# Altium schematic sheet FEZ-Bit-Power.SchDoc(FEZ-Bit-Power)
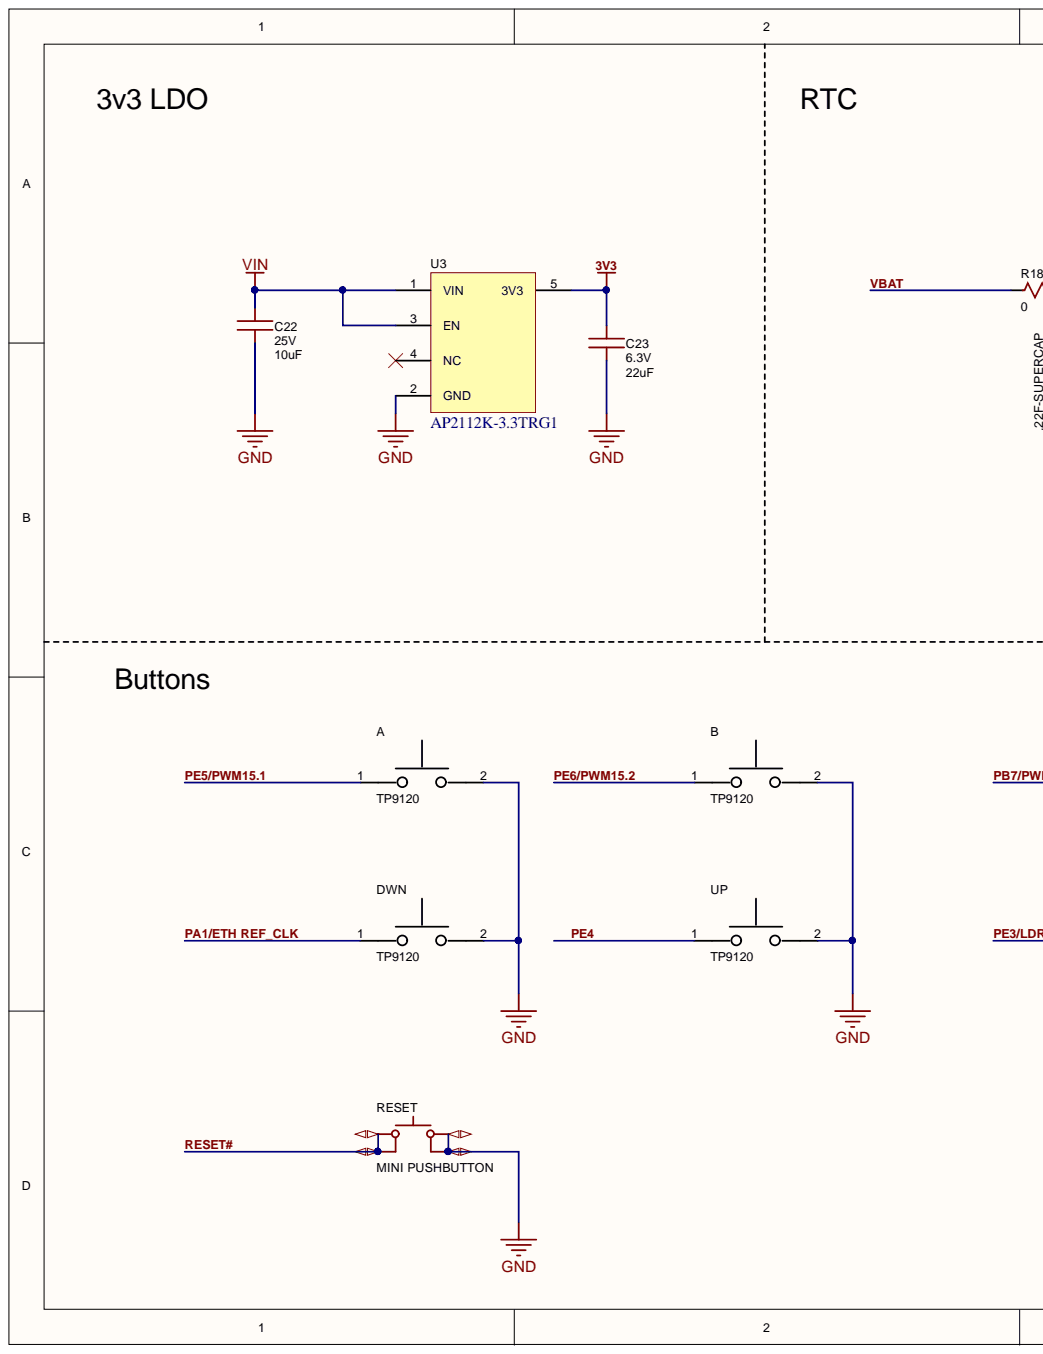
[diagram p0: FEZ-Bit-Power.SchDoc, left]
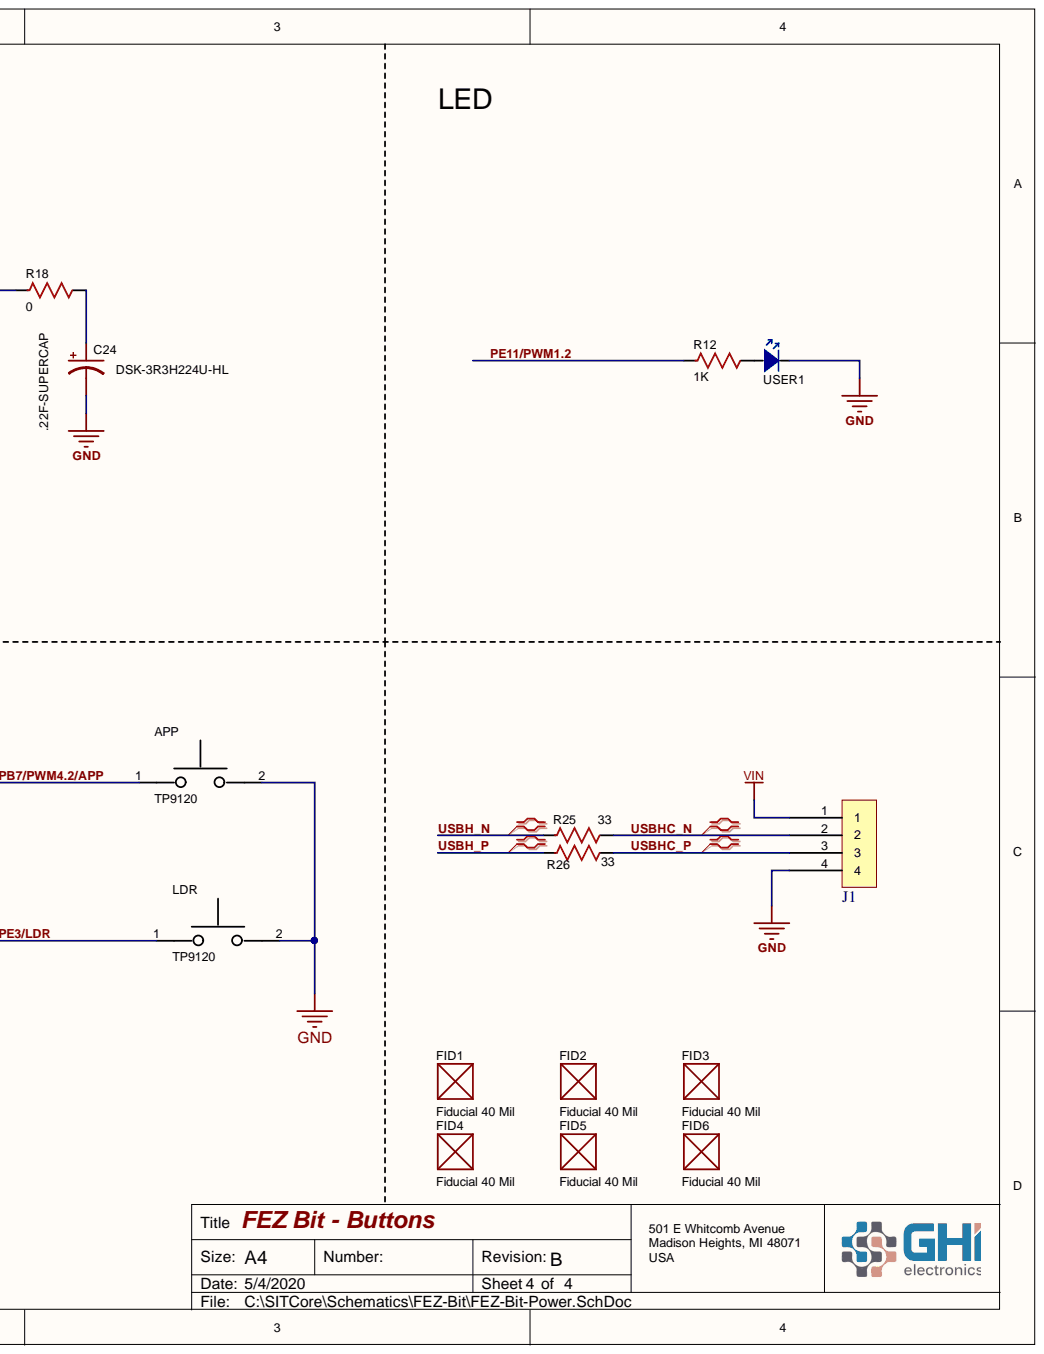
[diagram p1: FEZ-Bit-Power.SchDoc, right]

## Parts
A  value TP9120  [Button - TL9210]  (hidden comment: Button - TP9120)
APP  value TP9120  [Button - TL9210]  (hidden comment: Button - TP9120)
B  value TP9120  [Button - TL9210]  (hidden comment: Button - TP9120)
C22  value 10uF  Voltage: 25V  [C0805]  (hidden comment: 0805 Cap 10uF)
C23  value 22uF  Voltage: 6.3V  [C0805]  (hidden comment: 0805 Cap 22uF)
C24  .22F-SUPERCAP  value DSK-3R3H224U-HL  [DSK-RADIAL-6.8MM]
DWN  value TP9120  [Button - TL9210]  (hidden comment: Button - TP9120)
FID1  Fiducial 40 Mil  [Fiducial 40 Mil]  (no BOM)
FID2  Fiducial 40 Mil  [Fiducial 40 Mil]  (no BOM)
FID3  Fiducial 40 Mil  [Fiducial 40 Mil]  (no BOM)
FID4  Fiducial 40 Mil  [Fiducial 40 Mil]  (no BOM)
FID5  Fiducial 40 Mil  [Fiducial 40 Mil]  (no BOM)
FID6  Fiducial 40 Mil  [Fiducial 40 Mil]  (no BOM)
J1  M4  [1X04]  (value not drawn)
LDR  value TP9120  [Button - TL9210]  (hidden comment: Button - TP9120)
R12  value 1K  [R0603 (1608 Metric)]  (hidden comment: 0603 1K)
R18  value 0  [R0603]  (hidden comment: 0603 Res 0)
R25  value 33  [R0402]  (hidden comment: 0402 Res 33)
R26  value 33  [R0402]  (hidden comment: 0402 Res 33)
RESET  MINI_PUSHBUTTON  value MINI PUSHBUTTON  [MINI_PUSHBUTTON]
U3  AP2112K-3.3TRG1  [SOT95P280X100-5N]
UP  value TP9120  [Button - TL9210]  (hidden comment: Button - TP9120)
USER1  0603 LED Blue  [0603 LED Blue]  (value not drawn)

## Nets
3V3: C23.2 U3.5(3V3)
GND: A.2 APP.2 B.2 C22.1 C23.1 C24.- DWN.2 J1.4 LDR.2 RESET.3 RESET.4 U3.2(GND) UP.2 USER1.1
VIN: C22.2 J1.1 U3.1(VIN) U3.3(EN)
PA1/ETH REF_CLK: DWN.1
PB7/PWM4.2/APP: APP.1
PE3/LDR: LDR.1
PE4: UP.1
PE5/PWM15.1: A.1
PE6/PWM15.2: B.1
PE11/PWM1.2: R12.1
RESET#: RESET.1 RESET.2
USBH_N: R25.1
USBH_P: R26.1
USBHC_N: J1.2 R25.2
USBHC_P: J1.3 R26.2
VBAT: R18.1
NetC24_+: C24.+ R18.2
NetR12_2: R12.2 USER1.2

Not connected: U3 4(NC)

## Library data of the parts
C22 = 0805 Cap 10uF: 10uF ±10% 25V X5R 0805 Multilayer Ceramic Capacitors MLCC - SMD/SMT RoHS; Mfr.Part #: CC0805KKX5R8BB106; Manufacturer: YAGEO; Tolerance: ±10%; Package: 0805; LCSC Part #: C89831; Component Kind: Standard; Category: Ceramic; Capacitance: 10uF; Library Name: GHI-Passives.SchLib
C23 = 0805 Cap 22uF: 22uF ±20% 6.3V X5R 0805 Multilayer Ceramic Capacitors MLCC - SMD/SMT RoHS; Mfr.Part #: CL21A226MQCLRNC; Tolerance: ±20%; Package: 0805; LCSC Part #: C307530; Component Kind: Standard; Category: Ceramic; Capacitance: 22uF; Library Name: GHI-Passives.SchLib
C24 = .22F-SUPERCAP: ELNA DSK-621 - 220mF Electric Double Layer Capacitor (EDLC/SuperCapacitor)    A 220 mF SuperCapacitor. Used for backup power on devices with low standby current; Manufacturer: Elna America; Mfr.Part #: DSK-3R3H224U-HL; Digikey: 604-1020-1-ND; Component Kind: Standard; Library Name: GHI.SchLib
R12 = 0603 Res 1K: 1K ±5% 1/10W ±100ppm/℃ 0603 Chip Resistor - Surface Mount RoHS; Mfr.Part #: WR06X102 JTL; Manufacturer: Walsin Tech Corp; Voltage Rating: *V; Resistance Tolerance: ±5%; Resistance: 1K; Power Rating: 1/10W; Package: 0603; LCSC Part #: C384295; Component Kind: Standard; Library Name: GHI-Passives.SchLib
R18 = 0603 Res 0: ±5% 1/10W 0603 Chip Resistor - Surface Mount RoHS; Mfr.Part #: RC0603JR-070RL; Manufacturer: YAGEO; Voltage Rating: *V; Resistance Tolerance: ±5%; Resistance: 0; Power Rating: 1/10W; Package: 0603; LCSC Part #: C95177; Component Kind: Standard; Library Name: GHI-Passives.SchLib
R25 R26 = 0402 Res 33: 33 ±1% 1/16W ±100ppm/℃ 0402 Chip Resistor - Surface Mount RoHS; Mfr.Part #: RC0402FR-0733RL; Manufacturer: YAGEO; Voltage Rating: *V; Resistance Tolerance: ±1%; Resistance: 33; Power Rating: 1/16W; Package: 0402; LCSC Part #: C138002; Component Kind: Standard; Library Name: GHI-Passives.SchLib
U3 = AP2112K-3.3TRG1: Fixed 6V 3.3V 600mA SOT-25 Low Dropout Regulators(LDO) RoHS; Manufacturer: Diodes Incorporated; Value: AP2112K-3.3TRG1; Package: SOT-25; Mfr.Part #: AP2112K-3.3TRG1; LCSC Part #: C51118; ComponentLink1URL: http://www.diodes.com/_files/datasheets/AP2112.pdf; ComponentLink1Description: http://www.diodes.com/_files/datasheets/AP2112.pdf; Component Kind: Standard; Library Name: GHI-Power.SchLib
USER1 = 0603 LED Blue: Blue 468nm 0603 Light Emitting Diodes (LED) RoHS; Mfr.Part #: LTST-C193TBKT-5A; Manufacturer: Lite-On; Value: 0603 LED Blue; Package: 0603; LCSC Part #: C125103; Component Kind: Standard; Color: Blue; Library Name: GHI-Opto.SchLib
A APP B DWN LDR UP = Button - TP9120: PartNo: TP9120; Component Kind: Standard; Library Name: GHI.SchLib
J1 = M4: Library Name: Connectors.SchLib; Component Kind: Standard
RESET = MINI_PUSHBUTTON: Component Kind: Standard; Library Name: GHI.SchLib
FID1-FID6 = Fiducial 40 Mil: Library Name: GHI.SchLib
